# Altium schematic sheet Debug.SchDoc
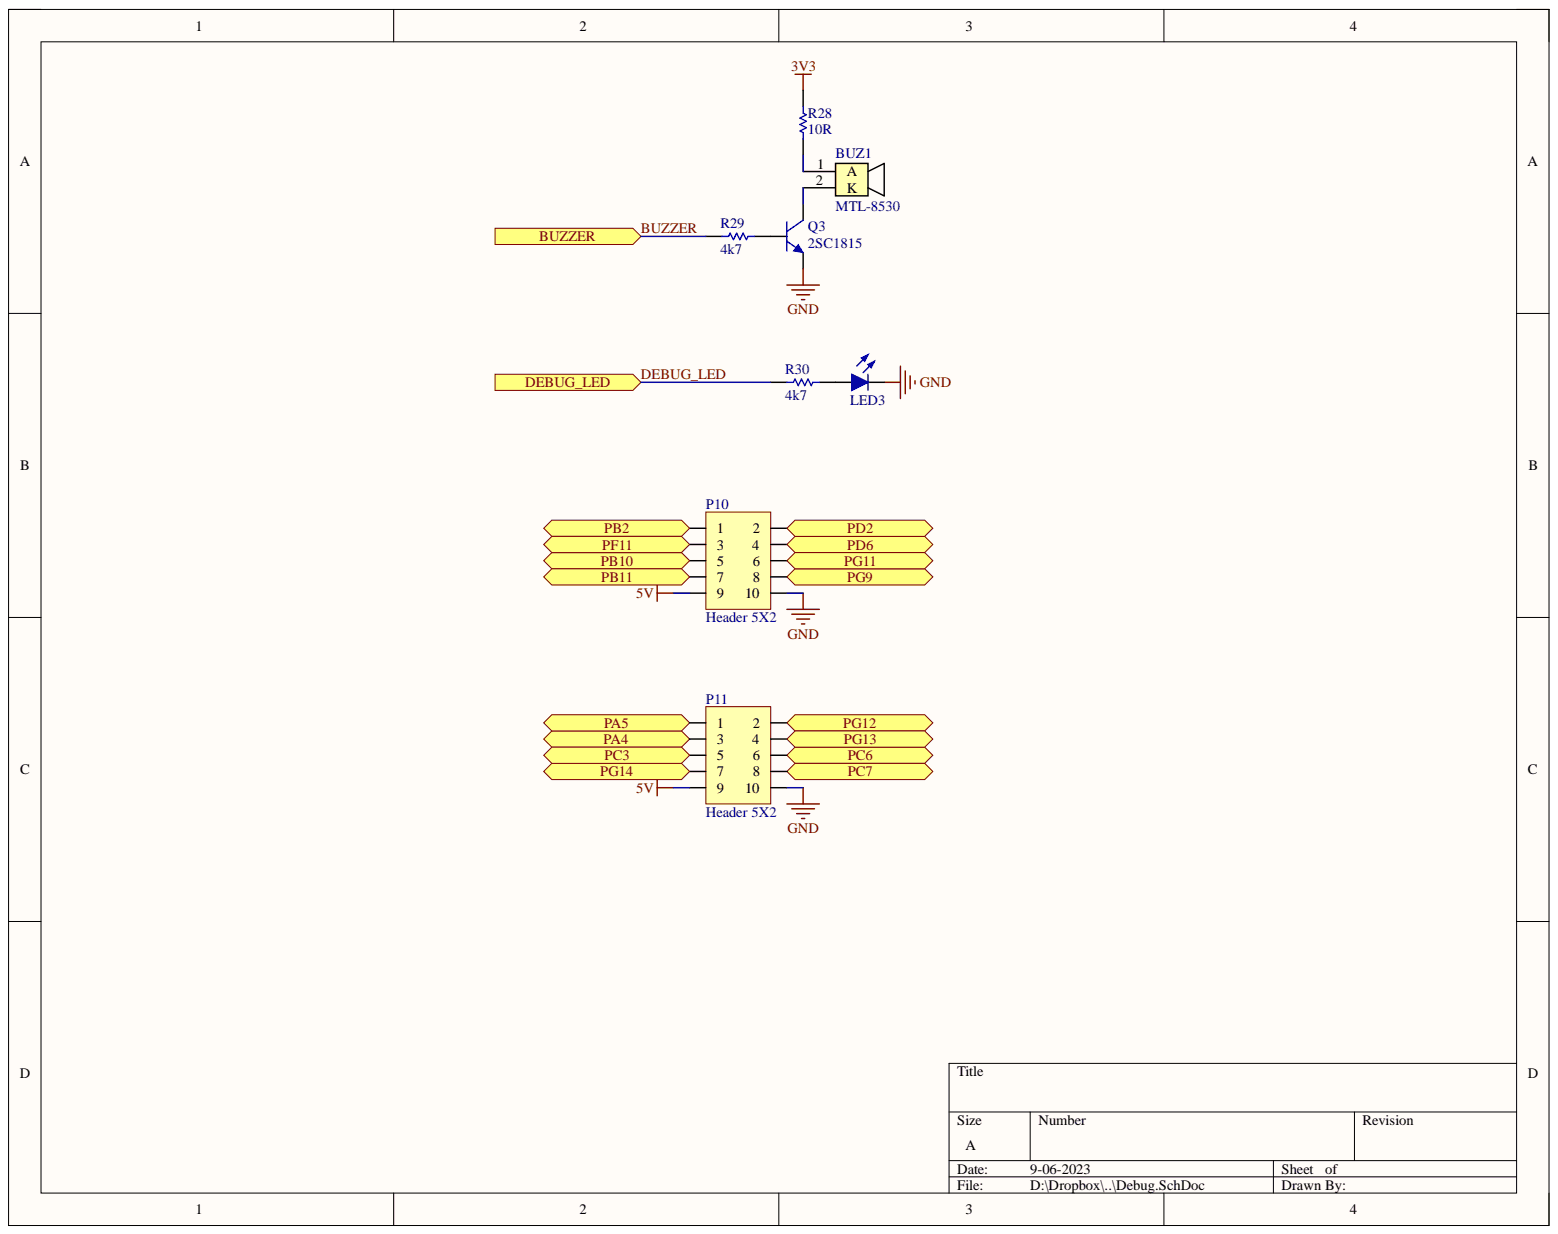
[diagram p0: Debug.SchDoc]

## Parts
BUZ1  MTL-8530  [MLT-8530]
LED3  Led-Blue  [Led SMD 0603 BLUE]  (value not drawn)
P10  Header 5X2  [IDC MBH 10]
P11  Header 5X2  [IDC MBH 10]
Q3  2SC1815  [SOT-23]
R28  10R  [R 0603 (1608)]
R29  4k7  [R 0603 (1608)]
R30  4k7  [R 0603 (1608)]

## Ports
BUZZER, DEBUG_LED, PA4, PA5, PB2, PB10, PB11, PC3, PC6, PC7, PD2, PD6, PF11, PG9, PG11, PG12, PG13, PG14

## Nets
3V3: R28.2
5V: P10.9 P11.9
GND: LED3.2 P10.10 P11.10 Q3.2
BUZZER: R29.1 ; port BUZZER
DEBUG_LED: R30.2 ; port DEBUG_LED
PA4: P11.3 ; port PA4
PA5: P11.1 ; port PA5
PB2: P10.1 ; port PB2
PB10: P10.5 ; port PB10
PB11: P10.7 ; port PB11
PC3: P11.5 ; port PC3
PC6: P11.6 ; port PC6
PC7: P11.8 ; port PC7
PD2: P10.2 ; port PD2
PD6: P10.4 ; port PD6
PF11: P10.3 ; port PF11
PG9: P10.8 ; port PG9
PG11: P10.6 ; port PG11
PG12: P11.2 ; port PG12
PG13: P11.4 ; port PG13
PG14: P11.7 ; port PG14
NetBUZ1_1: BUZ1.1 R28.1
NetBUZ1_2: BUZ1.2 Q3.3
NetLED3_1: LED3.1 R30.1
NetQ3_1: Q3.1 R29.2

## Library data of the parts
BUZ1 = Buzzer: Component Kind: Standard; Library Name: BKIT4U_LIB.SchLib
LED3 = Led: Typical LED; Component Kind: Standard; Library Name: BKIT4U_LIB.SchLib
P10 P11 = Header 5X2: Header, 5-Pin, Dual row; Component Kind: Standard; LatestRevisionDate: 17-Jul-2002; LatestRevisionNote: Re-released for DXP Platform.; Library Name: BKIT4U_LIB.SchLib; Publisher: Altium Limited
Q3 = Transistor NPN: NPN Bipolar Transistor; Component Kind: Standard; Library Name: BKIT4U_LIB.IntLib
R28-R30 = Resistor: Component Kind: Standard; Description: Resistor; LatestRevisionDate: 17-Jul-2002; LatestRevisionNote: Re-released for DXP Platform.; Library Name: BKIT4U_LIB.SchLib; PackageReference: AXIAL-0.3; Published: 8-Jun-2000; Publisher: Altium Limited
